# Altium schematic sheet Sheet1.SchDoc
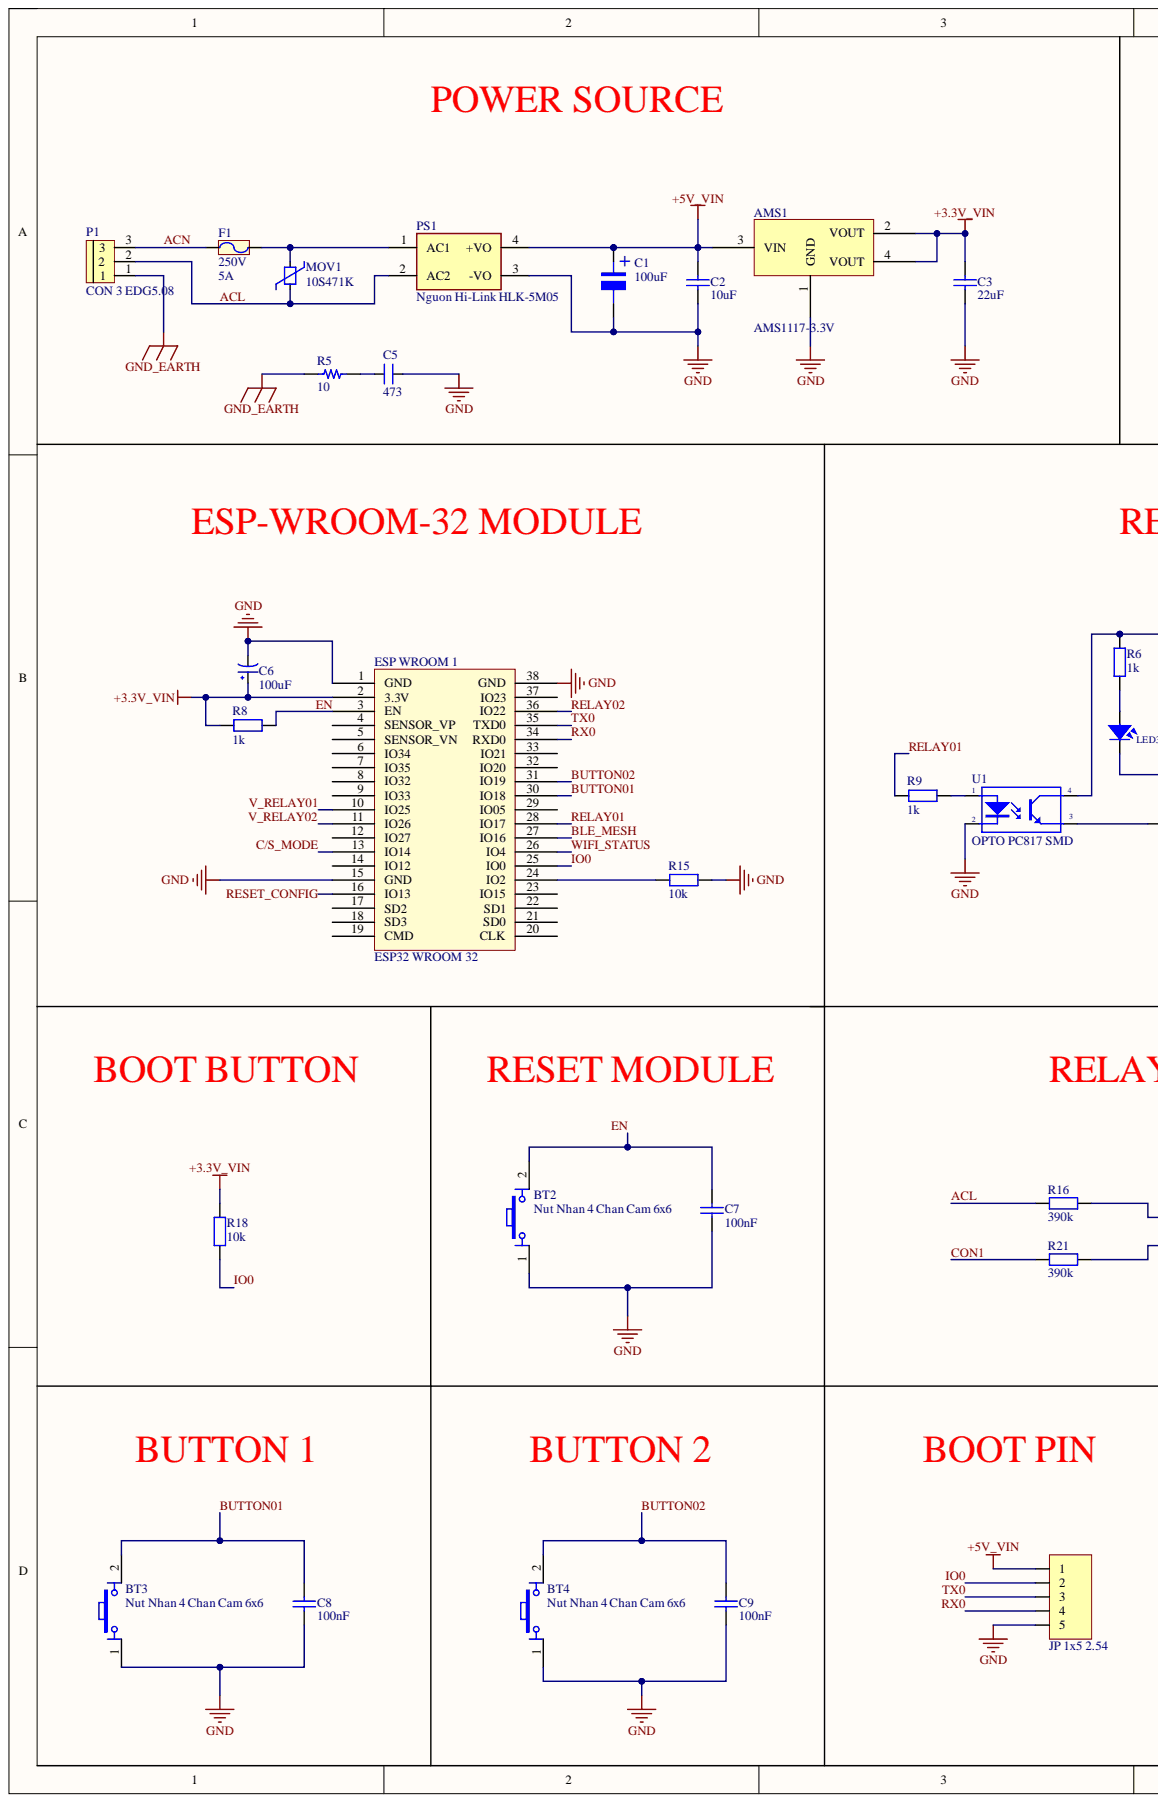
[diagram p0: Sheet1.SchDoc, left]
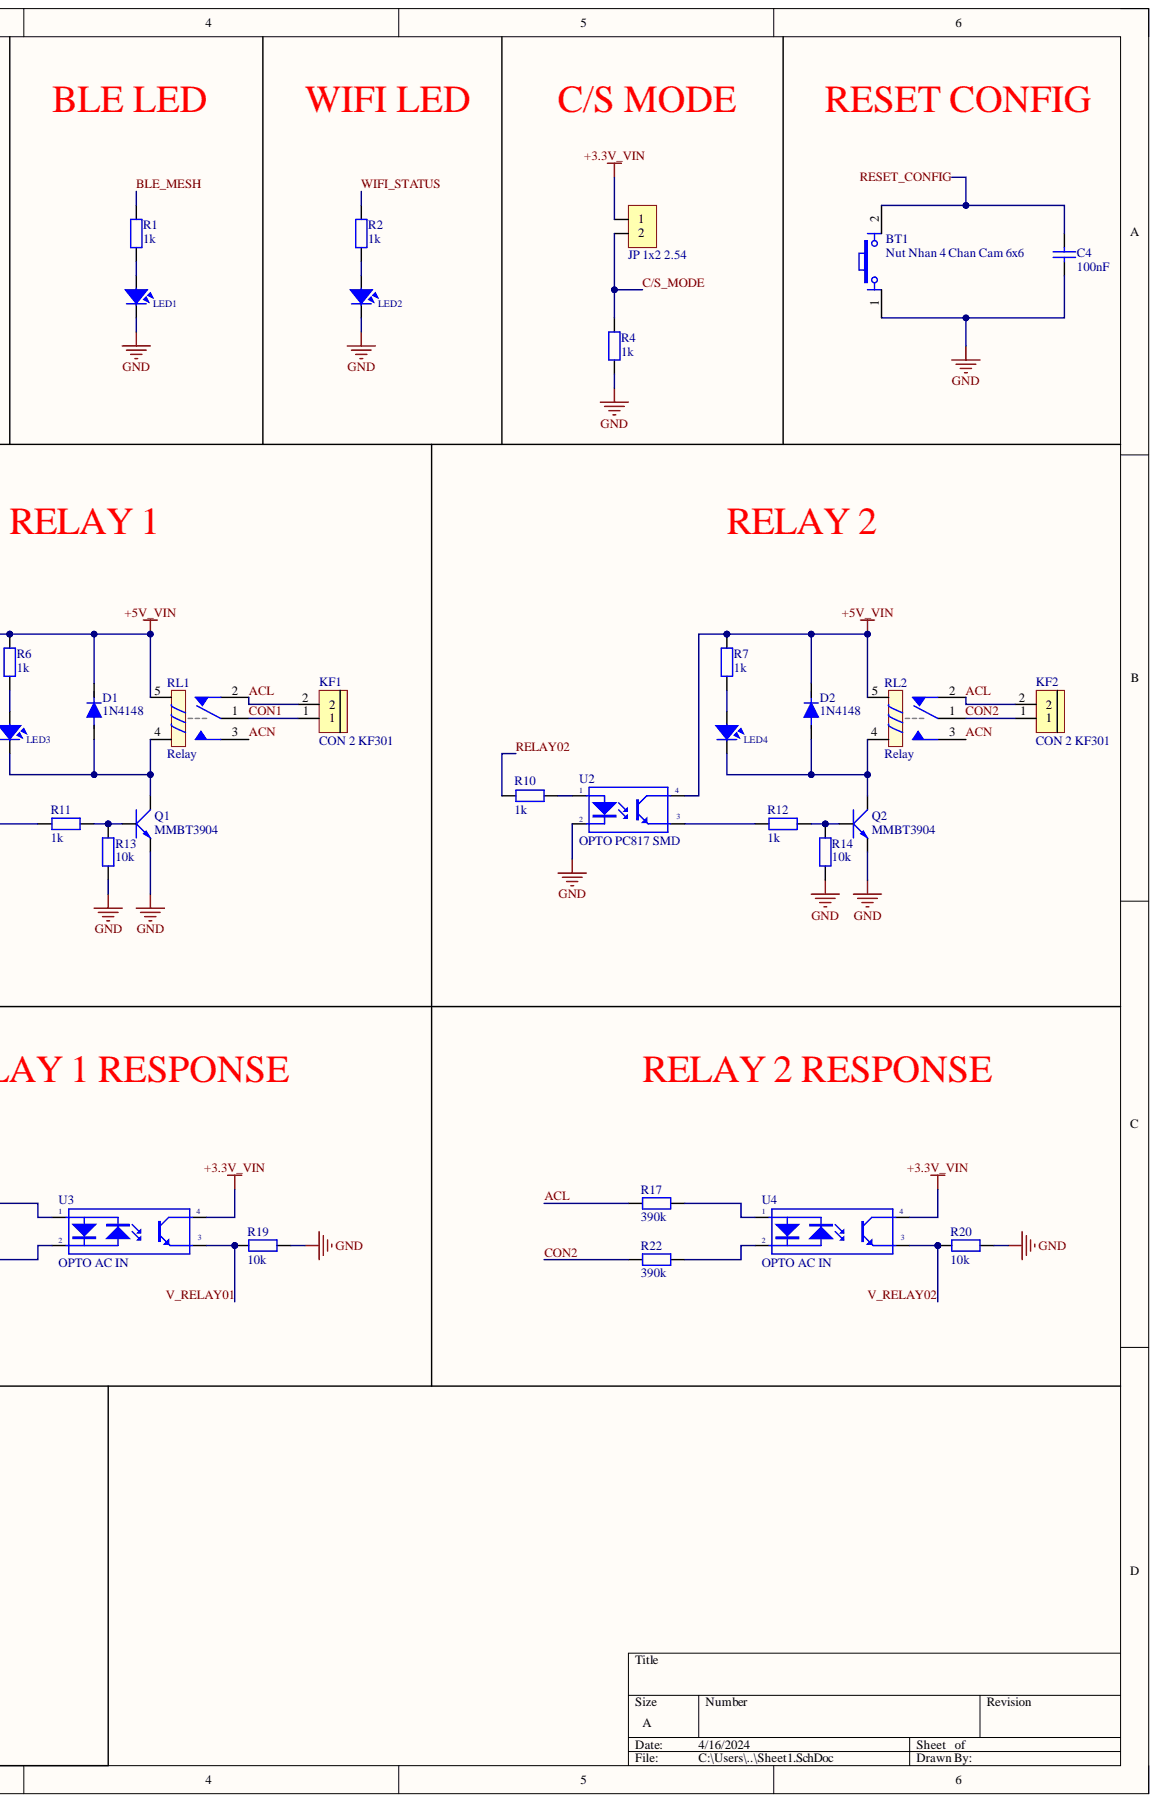
[diagram p1: Sheet1.SchDoc, right]

## Parts
AMS1  AMS1117-3.3V  [SOT223]
BT1  Nut Nhan 4 Chan Cam 6x6  [Nut Nhan 4 Chan Cam 6x6]
BT2  Nut Nhan 4 Chan Cam 6x6  [Nut Nhan 4 Chan Cam 6x6]
BT3  Nut Nhan 4 Chan Cam 6x6  [Nut Nhan 4 Chan Cam 6x6]
BT4  Nut Nhan 4 Chan Cam 6x6  [Nut Nhan 4 Chan Cam 6x6]
C1  100uF  [tu nhom 6.3 (d)]
C2  10uF  [C0603C/1608MM]
C3  22uF  [C0603C/1608MM]
C4  100nF  [C0603C/1608MM]
C5  473  [CN3]
C6  100uF  [tu tantalum smd3528]
C7  100nF  [C0603C/1608MM]
C8  100nF  [C0603C/1608MM]
C9  100nF  [C0603C/1608MM]
D1  1N4148  [SOD-323]
D2  1N4148  [SOD-323]
ESP_WROOM_1  ESP32 WROOM 32  [ESP32-WROOM-32D]
F1  Current Rating (Amps): 5A  Voltage - Rated: 250V  [FUSE_VERTICAL]  (hidden comment: 5A220V)
JP1  JP 1x2 2.54  [JP 1x2 DT]
JP2  JP 1x5 2.54  [JP 1x5 DT]
KF1  CON 2 KF301  [Con 2 KF301 5.08]
KF2  CON 2 KF301  [Con 2 KF301 5.08]
LED1  LED SMD  [SM-LED-0805-Blue]  (value not drawn)
LED2  LED SMD  [SM-LED-0805-Blue]  (value not drawn)
LED3  LED SMD  [SM-LED-0805-Blue]  (value not drawn)
LED4  LED SMD  [SM-LED-0805-Blue]  (value not drawn)
MOV1  10S471K
P1  CON 3 EDG5.08  [CON 3 3EDG5.08]
PS1  Nguon Hi-Link HLK-5M05  MP: HLK-5M05  [Nguon HI-LINK 5M05]
Q1  MMBT3904  [SOT 23]
Q2  MMBT3904  [SOT 23]
R1  1k  [tro smd 0603]
R2  1k  [tro smd 0603]
R4  1k  [tro smd 0603]
R5  10  [R1206B/3216MM]
R6  1k  [tro smd 0603]
R7  1k  [tro smd 0603]
R8  1k  [tro smd 0603]
R9  1k  [tro smd 0603]
R10  1k  [tro smd 0603]
R11  1k  [tro smd 0603]
R12  1k  [tro smd 0603]
R13  10k  [tro smd 0603]
R14  10k  [tro smd 0603]
R15  10k  [tro smd 0603]
R16  390k  [tro smd 1206]
R17  390k  [tro smd 1206]
R18  10k  [tro smd 0603]
R19  10k  [tro smd 0603]
R20  10k  [tro smd 0603]
R21  390k  [tro smd 1206]
R22  390k  [tro smd 1206]
RL1  Relay  [Relay 5 Chan SRD]
RL2  Relay  [Relay 5 Chan SRD]
U1  OPTO PC817 SMD  value PC817  [SOP4]
U2  OPTO PC817 SMD  value PC817  [SOP4]
U3  OPTO AC IN  [LTV-354T]
U4  OPTO AC IN  [LTV-354T]

## Nets
+3.3V_VIN: AMS1.2(VOUT) AMS1.4(VOUT) C3.2 C6.1 ESP_WROOM_1.2(3.3V) JP1.1 R8.2 R18.1 U3.4 U4.4
+5V_VIN: AMS1.3(VIN) C1.1 C2.2 D1.2 D2.2 JP2.1 PS1.4(+VO) R6.2 R7.2 RL1.5 RL2.5 U1.4 U2.4
GND: AMS1.1(GND) BT1.1 BT2.1 BT3.1 BT4.1 C1.2 C2.1 C3.1 C4.2 C5.2 C6.2 C7.2 C8.2 C9.2 ESP_WROOM_1.1(GND) ESP_WROOM_1.15(GND) ESP_WROOM_1.38(GND) JP2.5 LED1.2 LED2.2 PS1.3(-VO) Q1.2 Q2.2 R4.1 R13.1 R14.1 R15.1 R19.1 R20.1 U1.2 U2.2
GND_EARTH: P1.1 R5.1
ACL: KF1.2 KF2.2 MOV1.2 P1.2 PS1.2(AC2) R16.1 R17.1 RL1.2 RL2.2
ACN: F1.2 P1.3 RL1.3 RL2.3
BLE_MESH: ESP_WROOM_1.27(IO16) R1.2
BUTTON01: BT3.2 C8.1 ESP_WROOM_1.30(IO18)
BUTTON02: BT4.2 C9.1 ESP_WROOM_1.31(IO19)
C/S_MODE: ESP_WROOM_1.13(IO14) JP1.2 R4.2
CON1: KF1.1 R21.1 RL1.1
CON2: KF2.1 R22.1 RL2.1
EN: BT2.2 C7.1 ESP_WROOM_1.3(EN) R8.1
IO0: ESP_WROOM_1.25(IO0) JP2.2 R18.2
RELAY01: ESP_WROOM_1.28(IO17) R9.1
RELAY02: ESP_WROOM_1.36(IO22) R10.1
RESET_CONFIG: BT1.2 C4.1 ESP_WROOM_1.16(IO13)
RX0: ESP_WROOM_1.34(RXD0) JP2.4
TX0: ESP_WROOM_1.35(TXD0) JP2.3
V_RELAY01: ESP_WROOM_1.10(IO25) R19.2 U3.3
V_RELAY02: ESP_WROOM_1.11(IO26) R20.2 U4.3
WIFI_STATUS: ESP_WROOM_1.26(IO4) R2.2
NetC5_1: C5.1 R5.2
NetD1_1: D1.1 LED3.2 Q1.3 RL1.4
NetD2_1: D2.1 LED4.2 Q2.3 RL2.4
NetESP WROOM 1_24: ESP_WROOM_1.24(IO2) R15.2
NetF1_1: F1.1 MOV1.1 PS1.1(AC1)
NetLED1_1: LED1.1 R1.1
NetLED2_1: LED2.1 R2.1
NetLED3_1: LED3.1 R6.1
NetLED4_1: LED4.1 R7.1
NetQ1_1: Q1.1 R11.2 R13.2
NetQ2_1: Q2.1 R12.2 R14.2
NetR9_2: R9.2 U1.1
NetR10_2: R10.2 U2.1
NetR11_1: R11.1 U1.3
NetR12_1: R12.1 U2.3
NetR16_2: R16.2 U3.1
NetR17_2: R17.2 U4.1
NetR21_2: R21.2 U3.2
NetR22_2: R22.2 U4.2

Not connected: ESP_WROOM_1 4(SENSOR_VP) 5(SENSOR_VN) 6(IO34) 7(IO35) 8(IO32) 9(IO33) 12(IO27) 14(IO12) 17(SD2) 18(SD3) 19(CMD) 20(CLK) 21(SD0) 22(SD1) 23(IO15) 29(IO05) 32(IO20) 33(IO21) 37(IO23)

## Library data of the parts
AMS1 = AMS1117 SMD: Dán; Don_Vi: Con; Ma_Hang: MH000596; Value: AMS1117-3.3V SOT223; Chung_Loai: Dán; Component Kind: Standard; Library Name: Altium_Lib_by_KhueNguyenCreator.IntLib
BT1-BT4 = Nut Nhan 4 Chan Cam 6x6: Nut Nhan 4 Chan 6x6mm; Ma_Hang: MH004584; Ten: Nút Nhấn 4 Chân 6x6x5MM DIP; Component Kind: Standard; Library Name: Altium_Lib_by_KhueNguyenCreator.IntLib
C1 = Tu Nhom 100uF 16V: Dán; Don_Vi: Con; Ma_Hang: MH002219; So_Chan: 2; Ten_Linh_Kien: Tụ Nhôm 100uF 16V 6.3x5.4MM; Chung_Loai: Dán; Component Kind: Standard; Library Name: Altium_Lib_by_KhueNguyenCreator.IntLib
C2 C3 = CAP CER 10uF 16V X7R 0603: CAP CER 0603 10uF 16V X7R 10%; Component Kind: Standard; Library Name: Howard.SchLib
C4 C7-C9 = CAP CER 100nF 16V 5% X7R 0603: Cap Ceramic 0.1uF 16V X7R 5% SMD 0603 125C T/R; Manufacturer: Yageo; Manufacturer Part Number: CC0603JRX7R7BB104; Capacitance: 100nF; Case/Package: 0603; Case Code (Imperial): 0603; Case Code (Metric): 1608; Component Kind: Standard; ComponentLink1Description: https://www.verical.com/server-webapp/html/getDatasheet?partID=403543&src-supplier=Verical; ComponentLink1URL: https://www.verical.com/server-webapp/html/getDatasheet?partID=403543&src-supplier=Verical; Depth: 0.8mm; Dielectric: X7R; Halogen Free: Halogen Free; Lead Free: Lead Free; Length: 1.6mm; Max Operating Temperature: 125°C; Min Operating Temperature: -55°C; Mount: Surface Mount; Number of Pins: 2; Packaging: Tape and Reel; Pricing 1: 1=0.1, 10=0.077, 50=0.042, 100=0.0343, 500=0.02448, 1000=0.01923 (USD); Radiation Hardening: No; RoHS Compliant: Yes; Series: CC; Stock 1: 333951; Supplier 1: Digi-Key; Supplier Part Number 1: 311-1776-1-ND; Thickness: 0.035inch; Tolerance: 5%; Voltage Rating: 16V; Voltage Rating (DC): 16V; Width: 0.031inch; Library Name: Howard.SchLib
C5 = CN3: Cap np W0.3; Value: 0,047uF; Component Kind: Standard; Copyright: VanDungEvn; Library Name: syhaunguyen.IntLib
C6 = Tu tantalum 100uF 6.3V SMD3528: Dán; Don_Vi: Con; Ma_Hang: MH007595; So_Chan: 2; Ten_Linh_Kien: Tụ tantalum 107J-100uF 6.3V SMD3528; Chung_Loai: Dán; Component Kind: Standard; Library Name: Altium_Lib_by_KhueNguyenCreator.IntLib
D1 D2 = DIODE GEN PURP 75V 150MA DO35: Component Kind: Standard; Description: DIODE GEN PURP 75V 150MA DO35; Library Name: Howard.SchLib
F1 = Fuse: FUSE 220V 5A RADIAL; Breaking Capacity @ Rated Voltage: 50A; DC Cold Resistance: 0.03 Ohms; Melting I²t: 0.919; PROCESS_TYPE: MA; Response Time: 24S; Unit: PCS; CATEGORY: FUSE; Component Kind: Standard; Package / Case: TH2; Value: 5A220V; Library Name: Howard.SchLib
JP1 = JP 1x2 2.54 Duc Thang: Cắm; Don_Vi: Con; Ma_Hang: MH000903; Ten_Linh_Kien: Jump Đơn Đực 2.54MM 1x40P Thẳng 11MM; Chung_Loai: Cắm; Component Kind: Standard; Library Name: Altium_Lib_by_KhueNguyenCreator.IntLib
JP2 = JP 1x5 2.54 Duc Thang: Cắm; Don_Vi: Con; Ma_Hang: MH000903; Ten_Linh_Kien: Jump Đơn Đực 2.54MM 1x40P Thẳng 11MM; Chung_Loai: Cắm; Component Kind: Standard; Library Name: Altium_Lib_by_KhueNguyenCreator.IntLib
KF1 KF2 = CON 2 KF301: Cắm; Ma_Hang: MH000987; Ten_Linh_Kien: CON 2 KF301-2P 5.08MM Xanh Dương; Chung_Loai: Cắm; Component Kind: Standard; Don_Vi: Con; Library Name: Altium_Lib_by_KhueNguyenCreator.IntLib
LED1-LED4 = LED SMD 0805: Component Kind: Standard; Library Name: My library altium.IntLib
MOV1 = MOV 10S471K: VARISTOR 470V 2.5KA DISC 10MM; MANUFACTURER1: Bourns; MANUFACTURER1_PART#: MOV-10D391K; MANUFACTURER2_PART#: 10D391K 390V 10mm; LOH_CODE: CLA1220; Value: 10D391; CATEGORY: VARISTOR; Component Kind: Standard; MANUFACTURER2: http://linhkienchatluong.vn/tu-chong-set---varistor/tu-chong-set-varistor-10d391k-390v-10mm_sp1161_ct1335.aspx; Library Name: Howard.SchLib
P1 = CON 3 EDG5.08: Cắm; Ma_Hang: MH000760; Ten_Linh_Kien: CON 3 2EDG5.08-3P 5.08MM ( Xanh Cong); Chung_Loai: Cắm; Component Kind: Standard; Don_Vi: Con; Library Name: Altium_Lib_by_KhueNguyenCreator.IntLib
PS1 = Nguon Hi-Link HLK-5M05: AC DC 220V to 5V 5W 5Watt Isolated Switching Step-Down Power Supply Module Converter; Availability: Unavailable; Component Kind: Standard; MF: Hi-link; Package: Package Analog Devices; Price: None; SnapEDA_Link: https://www.snapeda.com/parts/HLK-5M05/Hi-link/view-part/2758659/?ref=snap; Library Name: Altium_Lib_by_KhueNguyenCreator.IntLib
Q1 Q2 = TRANS NPN 40V 0.2A SOT23: Bipolar (BJT) Transistor NPN 40 V 200 mA 300MHz 350 mW Surface Mount SOT-23; Manufacturer: MCC; Manufacturer Part Number: 2SD882-GR-BP; Collector-emitter Voltage-Max: 500mV; Collector Emitter Breakdown Voltage: 30V; Max Collector Current: 3A; Max Power Dissipation: 1.25W; Transition Frequency: 50MHz; Case/Package: TO-126-3; Component Kind: Standard; ComponentLink1Description: https://media.digikey.com/pdf/Data%20Sheets/Micro%20Commercial%20PDFs/2SD882-x.pdf?src-supplier=Digi-Key; ComponentLink1URL: https://media.digikey.com/pdf/Data%20Sheets/Micro%20Commercial%20PDFs/2SD882-x.pdf?src-supplier=Digi-Key; Max Operating Temperature: 150°C; Min Operating Temperature: -55°C; Mount: Through Hole; Packaging: Bulk; Series: -; Stock 1: 0; Supplier 1: Digi-Key; Supplier Part Number 1: 2SD882-GR-BP-ND; Library Name: Howard.SchLib
R1 R2 R4 R6-R12 = Tro 0603 1% 1k: Dán; Don_Vi: Con; Ma_Hang: MH006334; So_Chan: 2; Ten_Linh_Kien: Trở 0603 1% 1k; Chung_Loai: Dán; Component Kind: Standard; Library Name: Altium_Lib_by_KhueNguyenCreator.IntLib
R5 = RES SMD 3.3M OHM 5% 1/4W 1206: Surface Mount Thick Film Resistor, RC Series, 3.3Mohm, 100 mW, - 5%, 50 V, 1206; Manufacturer: Yageo; Manufacturer Part Number: RC1206JR-073M3L; Max Power Dissipation: 250mW; Resistance: 3.3MR; Temperature Coefficient: 100ppm/°C; Voltage Rating: 200V; Case/Package: 1206; Case Code (Imperial): 1206; Case Code (Metric): 3216; Component Kind: Standard; ComponentLink1Description: http://www.yageo.com.tw/exep/pages/download/literatures/PYu-R_INT-thick_7.pdf?src-supplier=Digi-Key; ComponentLink1URL: http://www.yageo.com.tw/exep/pages/download/literatures/PYu-R_INT-thick_7.pdf?src-supplier=Digi-Key; Construction: Rectangular; Depth: 1.6mm; Features: Moisture Resistant; Height: 0.026inch; Lead Free: Lead Free; Length: 0.122inch; Max Operating Temperature: 155°C; Military Standard: Not; Min Operating Temperature: -55°C; Number of Terminations: 2; Package Quantity: 5000; Packaging: Tape and Reel; Power Rating: 250mW; Pricing 1: 1=0.1, 10=0.063, 100=0.0258, 1000=0.01158, 2500=0.01005 (USD); Resistor Type: Thick Film; RoHS Compliant: Yes; Series: RC; Stock 1: 9900; Supplier 1: Digi-Key; Supplier Part Number 1: 311-3.3MERCT-ND; Termination: SMD/SMT; Tolerance: 5%; Voltage Rating (DC): 200V; Width: 0.063inch; Library Name: Howard.SchLib
R13-R15 R18-R20 = Tro 0603 1% 10k: Dán; Don_Vi: Con; Ma_Hang: MH006333; So_Chan: 2; Ten_Linh_Kien: Trở 0603 1% 10k; Chung_Loai: Dán; Component Kind: Standard; Library Name: Altium_Lib_by_KhueNguyenCreator.IntLib
R16 R17 R21 R22 = Tro 1206 1% 390k: Dán; Don_Vi: Con; Ma_Hang: MH005898; So_Chan: 2; Ten_Linh_Kien: Trở 1206 1% 390k; Chung_Loai: Dán; Component Kind: Standard; Library Name: Altium_Lib_by_KhueNguyenCreator.IntLib
RL1 RL2 = Relay 5 Chan SRD: Relay 5 Chan; Ma_Hang: MH009374; Ten: Relay 5V10A SRD-05VDC-SL-C Chính Hãng; Component Kind: Standard; Library Name: Altium_Lib_by_KhueNguyenCreator.IntLib
U1 U2 = OPTO PC817 SMD: Single Opto; Component Kind: Standard; Library Name: Altium_Lib_by_KhueNguyenCreator.IntLib
U3 U4 = LTV-354T: AC INPUT Opto; Component Kind: Standard; Library Name: Schlib1.SchLib
ESP_WROOM_1 = ESP32 WROOM 32: Component Kind: Standard; Library Name: Altium_Lib_by_KhueNguyenCreator.IntLib
